# Altium schematic sheet Sheet2.SchDoc
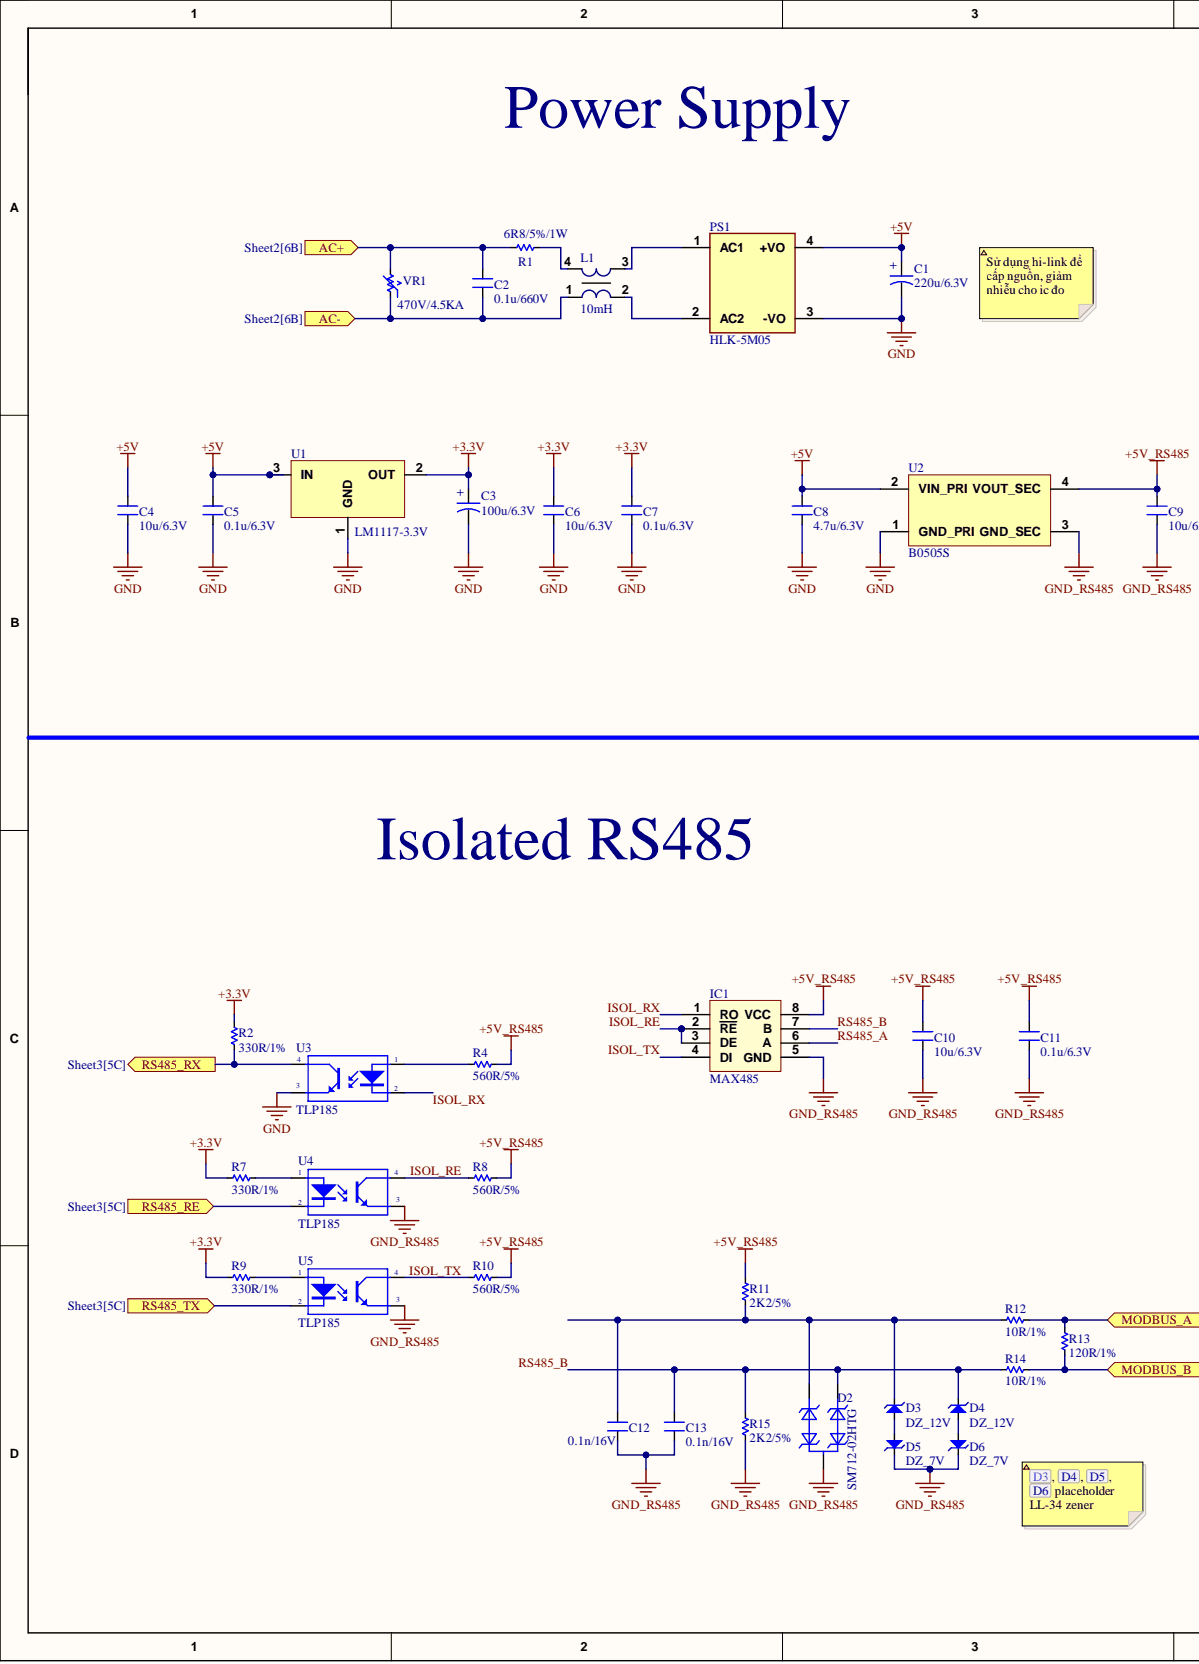
[diagram p0: Sheet2.SchDoc, left]
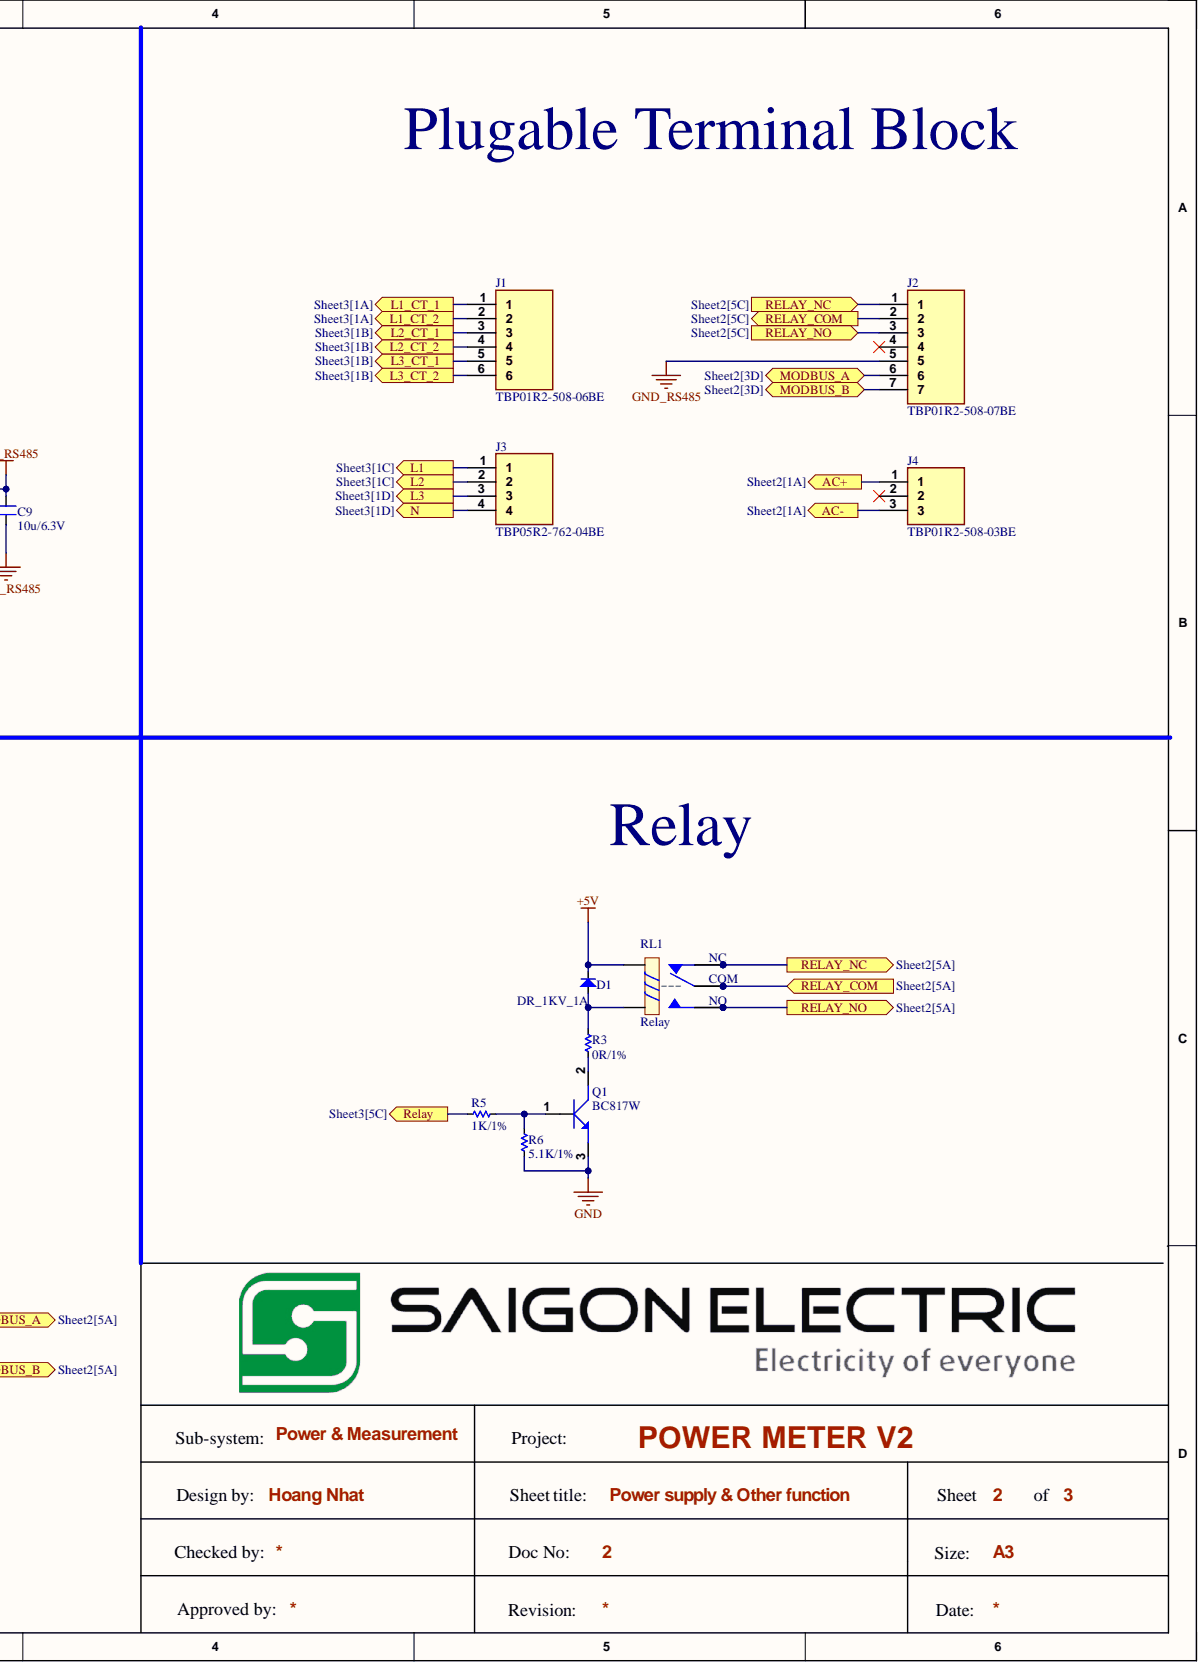
[diagram p1: Sheet2.SchDoc, right]

## Parts
C1  220u/6.3V  [CAP-ALU-SMD-6.3x7.7x2.2]
C2  0.1u/660V  [CAPRR1500W60L1750T900H1600]
C3  100u/6.3V  [CAP-ALU-SMD-6.3x7.7x2.2]
C4  10u/6.3V  [CAP_C_2012X110]
C5  0.1u/6.3V  [CAP_C_2012X110]
C6  10u/6.3V  [CAP_C_2012X110]
C7  0.1u/6.3V  [CAP_C_2012X110]
C8  4.7u/6.3V  [CAP_C_2012X110]
C9  10u/6.3V  [CAP_C_2012X110]
C10  10u/6.3V  [CAP_C_2012X110]
C11  0.1u/6.3V  [CAP_C_2012X110]
C12  0.1n/16V  [CAP_C_2012X110]
C13  0.1n/16V  [CAP_C_2012X110]
D1  DR_1KV_1A  [DIOM5025X26N]
D2  SM712-02HTG  [SOT95P237X112-3N]
D3  DZ_12V  [LL-34]
D4  DZ_12V  [LL-34]
D5  DZ_7V  [LL-34]
D6  DZ_7V  [LL-34]
IC1  MAX485  [SOIC-8]
J1  TBP01R2-508-06BE  [CUI_TBP01R2-508-06BE]
J2  TBP01R2-508-07BE  [CUI_TBP01R2-508-07BE]
J3  TBP05R2-762-04BE  [CUI_TBP05R2-762-04BE]
J4  TBP01R2-508-03BE  [CUI_TBP01R2-508-03BE]
L1  10mH  [UU98LFH142LF]
PS1  HLK-5M05  [CONV_HLK-5M05]
Q1  BC817W  [SOT95P237X112-3N]
R1  6R8/5%/1W  [RES-1W]
R2  330R/1%  [RES_C_2012X65]
R3  0R/1%  [RES_C_2012X65]
R4  560R/5%  [RES_C_2012X65]
R5  1K/1%  [RES_C_2012X65]
R6  5.1K/1%  [RES_C_2012X65]
R7  330R/1%  [RES_C_2012X65]
R8  560R/5%  [RES_C_2012X65]
R9  330R/1%  [RES_C_2012X65]
R10  560R/5%  [RES_C_2012X65]
R11  2K2/5%  [RES_C_2012X65]
R12  10R/1%  [RES_C_3216X70]
R13  120R/1%  [RES_C_3216X70]
R14  10R/1%  [RES_C_3216X70]
R15  2K2/5%  [RES_C_2012X65]
RL1  Relay  [RELAY_9-1393792-1]
U1  LM1117-3.3V  [SOT230P700X180-4N]
U2  B0505S  [B0505S-1W]
U3  TLP185  [SOP254P700X220-4N]
U4  TLP185  [SOP254P700X220-4N]
U5  TLP185  [SOP254P700X220-4N]
VR1  470V/4.5KA  [B72214P2301K101]

## Ports
AC+, AC-, L1, L1_CT_1, L1_CT_2, L2, L2_CT_1, L2_CT_2, L3, L3_CT_1, L3_CT_2, MODBUS_A, MODBUS_B, N, Relay, RELAY_COM, RELAY_NC, RELAY_NO, RS485_RE, RS485_RX, RS485_TX

## Nets
+3.3V: C3.1 C6.2 C7.2 R2.1 R7.1 R9.1 U1.2
+5V: C1.1 C4.2 C5.2 C8.2 D1.2 PS1.4(+VO) RL1.1 U1.3 U2.2(VIN_PRI)
+5V_RS485: C9.2 C10.2 C11.2 IC1.8(VCC) R4.2 R8.2 R10.2 R11.2 U2.4(VOUT_SEC)
GND: C1.2 C3.2 C4.1 C5.1 C6.1 C7.1 C8.1 PS1.3(-VO) Q1.3 R6.1 U1.1 U2.1(GND_PRI) U3.3
GND_RS485: C9.1 C10.1 C11.1 C12.1 C13.1 D2.3 D5.1 D6.1 IC1.5(GND) J2.5 R15.1 U2.3(GND_SEC) U4.3 U5.3
ISOL_RE: IC1.2(RE) IC1.3(DE) R8.1 U4.4
ISOL_RX: IC1.1(RO) U3.2
ISOL_TX: IC1.4(DI) R10.1 U5.4
L1: J3.1 ; port L1
L1_CT_1: J1.1 ; port L1_CT_1
L1_CT_2: J1.2 ; port L1_CT_2
L2: J3.2 ; port L2
L2_CT_1: J1.3 ; port L2_CT_1
L2_CT_2: J1.4 ; port L2_CT_2
L3: J3.3 ; port L3
L3_CT_1: J1.5 ; port L3_CT_1
L3_CT_2: J1.6 ; port L3_CT_2
MODBUS_A: J2.6 R12.2 R13.2 ; port MODBUS_A
MODBUS_B: J2.7 R13.1 R14.2 ; port MODBUS_B
N: J3.4 ; port N
Relay: R5.1 ; port Relay
RELAY_COM: J2.2 RL1.6 RL1.11 ; port RELAY_COM
RELAY_NC: J2.1 RL1.4 RL1.13 ; port RELAY_NC
RELAY_NO: J2.3 RL1.8 RL1.9 ; port RELAY_NO
RS485_A: IC1.6(A)
RS485_B: C13.2 D2.1 D4.1 IC1.7(B) R14.1 R15.2
RS485_RE: U4.2 ; port RS485_RE
RS485_RX: R2.2 U3.4 ; port RS485_RX
RS485_TX: U5.2 ; port RS485_TX
NetC2_1: C2.1 J4.3 L1.1 VR1.2
NetC2_2: C2.2 J4.1 R1.1 VR1.1
NetC12_2: C12.2 D2.2 D3.1 R11.1 R12.1
NetD1_1: D1.1 R3.2 RL1.16
NetD3_2: D3.2 D5.2
NetD4_2: D4.2 D6.2
NetL1_2: L1.2 PS1.2(AC2)
NetL1_3: L1.3 PS1.1(AC1)
NetL1_4: L1.4 R1.2
NetQ1_1: Q1.1 R5.2 R6.2
NetQ1_2: Q1.2 R3.1
NetR4_1: R4.1 U3.1
NetR7_2: R7.2 U4.1
NetR9_2: R9.2 U5.1

Not connected: J2 4; J4 2

## Library data of the parts
C1 C3 = CAP_POLAR: Component Kind: Standard; Library Name: PowerMeter.SchLib
C2 C4-C13 = CAP_SMD: Component Kind: Standard; Library Name: DAK_Altium_Integrated_Lib.IntLib
D1 = Diode: Default Diode; Code - JEDEC: DO-214-AB; Value: 1N5007; Component Kind: Standard; Library Name: Library.SchLib; Published: 8-Jun-2000; Revision: July-2002: Re-released for DXP Platform.; Publisher: Altium Limited
D2 = SM712-02HTG: TVS Diode, SM712 Series, 31V Clamp, 600W Peak Pulse, Surface Mount, SOT23-3 | Littelfuse SM712-02HTG; MF: Littelfuse Inc.; Check_prices: https://www.snapeda.com/parts/SM712-02HTG/Littelfuse/view-part/?ref=eda; Component Kind: Standard; Library Name: PowerMeter.SchLib; MP: SM712-02HTG; Package: SOT-23 Littelfuse Inc.; SnapEDA_Link: https://www.snapeda.com/parts/SM712-02HTG/Littelfuse/view-part/?ref=snap
D3-D6 = DIODE Zener: Zenner; Component Kind: Standard; Library Name: PowerMeter.SchLib
IC1 = MAX485: RS232 - RS485 (Same sn75176); Component Kind: Standard; Library Name: PowerMeter.SchLib
J1 = TBP01R2-508-06BE: 2~24 Ð¿Ð¾Ð»ÑŽÑÐ°, Ð¿Ð¾Ð´ÐºÐ»ÑŽÑ‡Ð°ÐµÐ¼Ñ‹Ð¹, Ð²ÐµÑ€Ñ‚Ð¸ÐºÐ°Ð»ÑŒÐ½Ñ‹Ð¹, ÑˆÐ°Ð³ 5,08, ÐºÐ»ÐµÐ¼Ð¼Ð½Ñ‹Ð¹ Ð±Ð»Ð¾Ðº; MF: Same Sky; Check_prices: https://www.snapeda.com/parts/TBP01R2-508-06BE/Same+Sky/view-part/?ref=eda; Component Kind: Standard; Library Name: PowerMeter.SchLib; MP: TBP01R2-508-06BE; Package: None; SnapEDA_Link: https://www.snapeda.com/parts/TBP01R2-508-06BE/Same+Sky/view-part/?ref=snap
J2 = TBP01R2-508-07BE: 2~24 Poles, Pluggable, Receptacle, Vertical, 5.08 Pitch, Terminal Block Connector; MF: Same Sky; Check_prices: https://www.snapeda.com/parts/TBP01R2-508-07BE/Same+Sky/view-part/?ref=eda; Component Kind: Standard; Library Name: PowerMeter.SchLib; MP: TBP01R2-508-07BE; Package: None; SnapEDA_Link: https://www.snapeda.com/parts/TBP01R2-508-07BE/Same+Sky/view-part/?ref=snap
J3 = TBP05R2-762-04BE: 2~24 Poles, Pluggable, Receptacle, Vertical, 7.62 Pitch, Terminal Block Connector; MF: Same Sky; Check_prices: https://www.snapeda.com/parts/TBP05R2-762-04BE/Same+Sky/view-part/?ref=eda; Component Kind: Standard; Library Name: PowerMeter.SchLib; MP: TBP05R2-762-04BE; Package: None; SnapEDA_Link: https://www.snapeda.com/parts/TBP05R2-762-04BE/Same+Sky/view-part/?ref=snap
J4 = TBP01R2-508-03BE: 2~24 Poles, Pluggable, Receptacle, Vertical, 5.08 Pitch, Terminal Block Connector; MF: Same Sky; Check_prices: https://www.snapeda.com/parts/TBP01R2-508-03BE/Same+Sky/view-part/?ref=eda; Component Kind: Standard; Library Name: PowerMeter.SchLib; MP: TBP01R2-508-03BE; Package: None; SnapEDA_Link: https://www.snapeda.com/parts/TBP01R2-508-03BE/Same+Sky/view-part/?ref=snap
L1 = L-DIP-2: Manufacturer: Würth Elektronik eiSos GmbH; partNo: 744284100; Component Kind: Standard; ComponentLink1Description: Manufacturer; ComponentLink1URL: http://www.we-online.de; ComponentLink2Description: Datasheet; ComponentLink2URL: http://www.we-online.de/katalog/media/pdf/744284100.pdf; Created Date: March 2012; Inductance: 10mH; Library Name: Library.SchLib; Created by: Christin Zierenner
PS1 = HLK-5M05: AC DC 220V to 5V 5W 5Watt Isolated Switching Step-Down Power Supply Module Converter; MF: Hi-link; Availability: Not in stock; Check_prices: https://www.snapeda.com/parts/HLK-5M05/Hi-link/view-part/?ref=eda; Component Kind: Standard; Library Name: PowerMeter.SchLib; MP: HLK-5M05; Package: Package; Price: None; SnapEDA_Link: https://www.snapeda.com/parts/HLK-5M05/Hi-link/view-part/?ref=snap
Q1 = BJT_NPN: Component Kind: Standard; Library Name: PowerMeter.SchLib
R1-R15 = Res-SMD: Resistor; Manufacturer: Generic Components; Class: Passive; Component Kind: Standard; Library Name: Library.SchLib; Published: 08/06/2000; Publisher: Altium Hobart Technology Centre
RL1 = Relay: Value: Relay SPDT, 10A, 12V; Component Kind: Standard; Library Name: PowerMeter.SchLib
U1 = LM1117: 800mA Low-Dropout Linear Regulator; Component Kind: Standard; Library Name: PowerMeter.SchLib; Website: http://group3i.net; Creator: Nguyen Ba Bien
U3-U5 = TLP185: Single Opto; Value: PC817; Component Kind: Standard; Library Name: PowerMeter.SchLib
VR1 = Varistor: MOV-14D471K, Varistors 470V 14mm; Component Kind: Standard; Library Name: PowerMeter.SchLib
U2 = B0505S: Component Kind: Standard; Library Name: PowerMeter.SchLib
